# Altium schematic sheet BatteryCharger.SchDoc
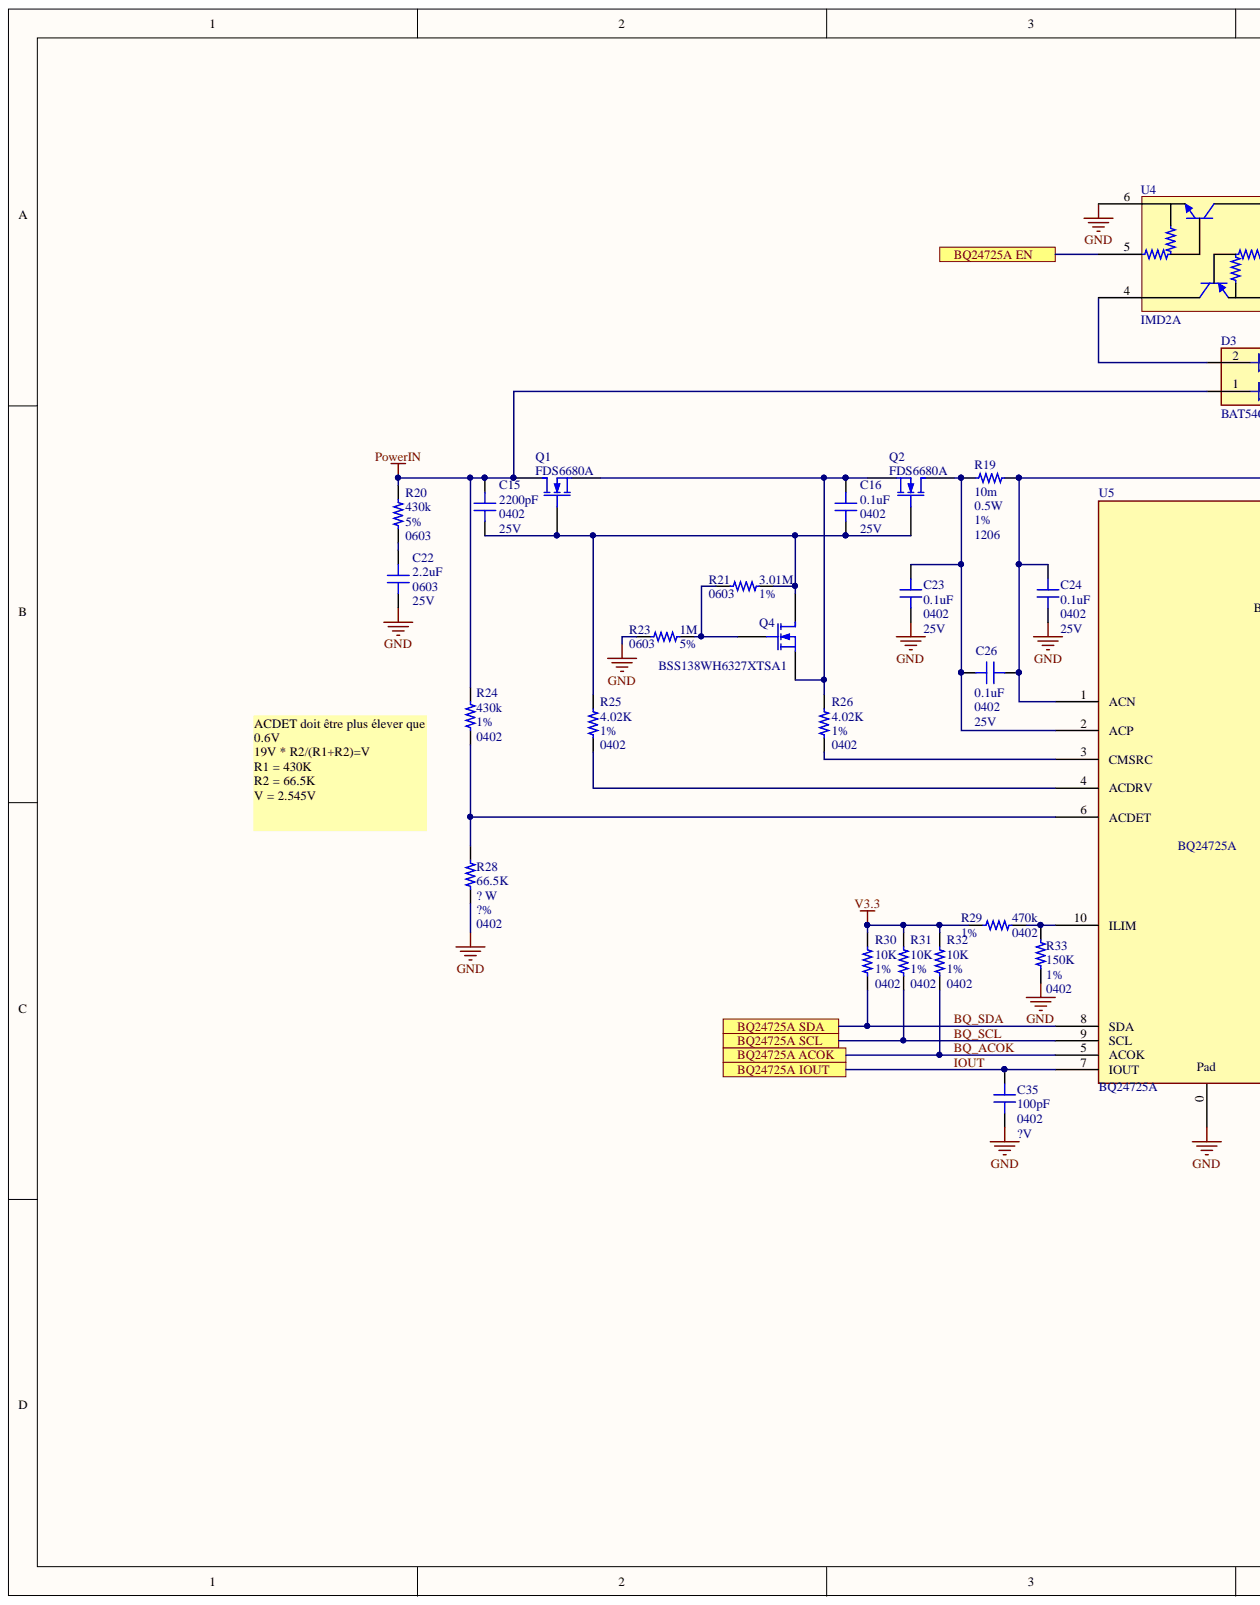
[diagram p0: BatteryCharger.SchDoc, left]
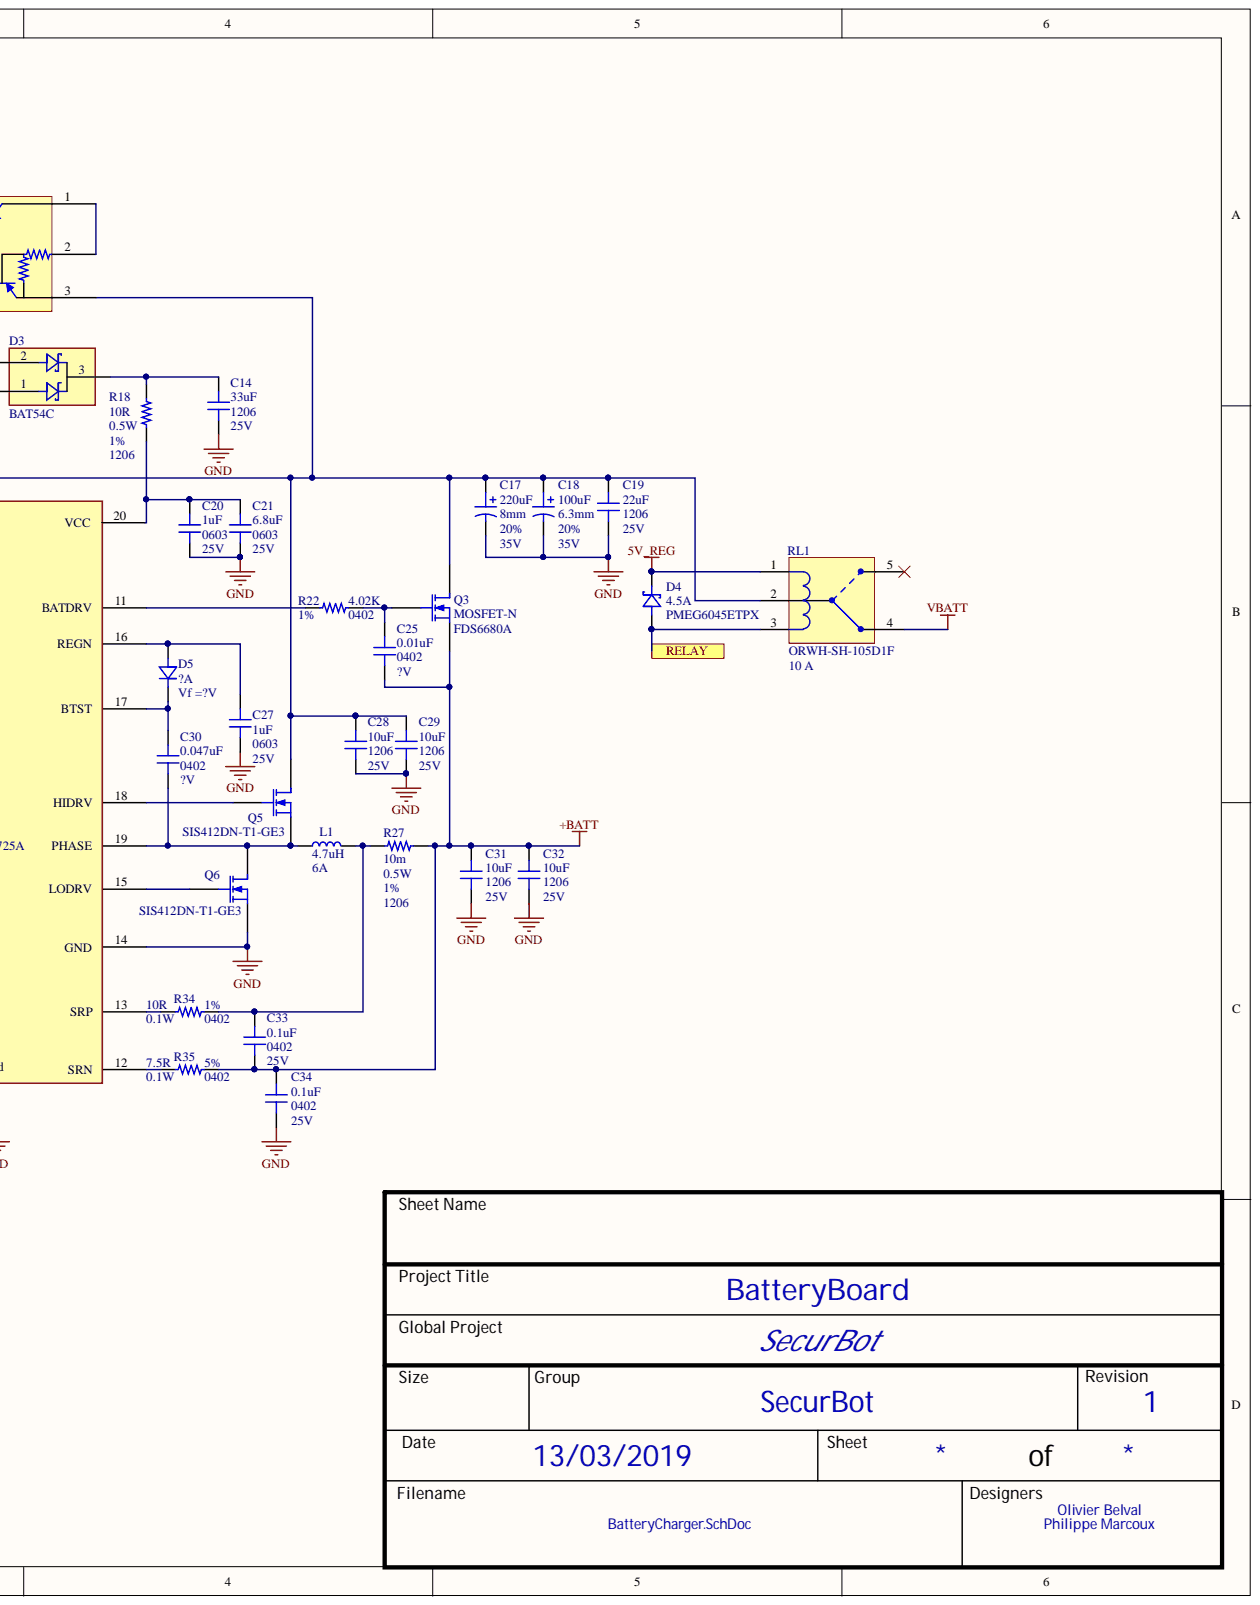
[diagram p1: BatteryCharger.SchDoc, right]

## Parts
C14  value 33uF  Package: 1206  Voltage: 25V  [CAP_1206]  (hidden comment: CAP - 1206)
C15  value 2200pF  Package: 0402  Voltage: 25V  [CAP_0402]  (hidden comment: CAP - 0402)
C16  value 0.1uF  Package: 0402  Voltage: 25V  [CAP_0402]  (hidden comment: CAP - 0402)
C17  value 220uF  Package: 8mm  Tolerance: 20%  Voltage: 35V  [CAP UWT 8x10]  (hidden comment: CAP ALU - 6.3mm)
C18  value 100uF  Package: 6.3mm  Tolerance: 20%  Voltage: 35V  [CAP ALU - 6.3mm DIA]  (hidden comment: CAP ALU - 6.3mm)
C19  value 22uF  Package: 1206  Voltage: 25V  [CAP_1206]  (hidden comment: CAP - 1206)
C20  value 1uF  Package: 0603  Voltage: 25V  [CAP_0603]  (hidden comment: CAP - 0603)
C21  value 6.8uF  Package: 0603  Voltage: 25V  [CAP_0603]  (hidden comment: CAP - 0603)
C22  value 2.2uF  Package: 0603  Voltage: 25V  [CAP_0603]  (hidden comment: CAP - 0603)
C23  value 0.1uF  Package: 0402  Voltage: 25V  [CAP_0402]  (hidden comment: CAP - 0402)
C24  value 0.1uF  Package: 0402  Voltage: 25V  [CAP_0402]  (hidden comment: CAP - 0402)
C25  value 0.01uF  Package: 0402  Voltage: ?V  [CAP_0402]  (hidden comment: CAP - 0402)
C26  value 0.1uF  Package: 0402  Voltage: 25V  [CAP_0402]  (hidden comment: CAP - 0402)
C27  value 1uF  Package: 0603  Voltage: 25V  [CAP_0603]  (hidden comment: CAP - 0603)
C28  value 10uF  Package: 1206  Voltage: 25V  [CAP_1206]  (hidden comment: CAP - 1206)
C29  value 10uF  Package: 1206  Voltage: 25V  [CAP_1206]  (hidden comment: CAP - 1206)
C30  value 0.047uF  Package: 0402  Voltage: ?V  [CAP_0402]  (hidden comment: CAP - 0402)
C31  value 10uF  Package: 1206  Voltage: 25V  [CAP_1206]  (hidden comment: CAP - 1206)
C32  value 10uF  Package: 1206  Voltage: 25V  [CAP_1206]  (hidden comment: CAP - 1206)
C33  value 0.1uF  Package: 0402  Voltage: 25V  [CAP_0402]  (hidden comment: CAP - 0402)
C34  value 0.1uF  Package: 0402  Voltage: 25V  [CAP_0402]  (hidden comment: CAP - 0402)
C35  value 100pF  Package: 0402  Voltage: ?V  [CAP_0402]  (hidden comment: CAP - 0402)
D3  BAT54C  [BAT54C]
D4  Current: 4.5A  Part number: PMEG6045ETPX  [DO-214AC_SOD128]  (hidden comment: SCHOTTKEY)
D5  Current: ?A  [BAT54]  (hidden comment: DIODE BAT54)
L1  value 4.7uH  Current: 6A  [ASPI-0630LR]  (hidden comment: IND)
Q1  Part number: FDS6680A  [SO-8 FDS6680AS]  (hidden comment: MOSFET-N)
Q2  Part number: FDS6680A  [SO-8 FDS6680AS]  (hidden comment: MOSFET-N)
Q3  MOSFET-N  Part number: FDS6680A  [SO-8 FDS6680AS]
Q4  Part number: BSS138WH6327XTSA1  [PG-SOT-323]  (hidden comment: MOSFET-N)
Q5  Part number: SIS412DN-T1-GE3  [SiS412DN]  (hidden comment: MOSFET-N)
Q6  Part number: SIS412DN-T1-GE3  [SiS412DN]  (hidden comment: MOSFET-N)
R18  value 10R  Package: 1206  Tolerance: 1%  Watt: 0.5W  [RES_1206]  (hidden comment: RES - 1206)
R19  value 10m  Watt: 0.5W  [RES_1206]  (hidden comment: RES - 1206)
R20  value 430k  Package: 0603  Tolerance: 5%  [RES_0603]  (hidden comment: RES - 0603)
R21  value 3.01M  Package: 0603  Tolerance: 1%  [RES_0603]  (hidden comment: RES - 0603)
R22  value 4.02K  Package: 0402  Tolerance: 1%  [RES_0402]  (hidden comment: RES - 0402)
R23  value 1M  Package: 0603  Tolerance: 5%  [RES_0603]  (hidden comment: RES - 0603)
R24  value 430k  Package: 0402  Tolerance: 1%  [RES_0402]  (hidden comment: RES - 0402)
R25  value 4.02K  Package: 0402  Tolerance: 1%  [RES_0402]  (hidden comment: RES - 0402)
R26  value 4.02K  Package: 0402  Tolerance: 1%  [RES_0402]  (hidden comment: RES - 0402)
R27  value 10m  Tolerance: 1%  Watt: 0.5W  [RES_1206]  (hidden comment: RES - 1206)
R28  value 66.5K  Package: 0402  Tolerance: ?%  Watt: ? W  [RES_0402]  (hidden comment: RES - 0402)
R29  value 470k  Package: 0402  Tolerance: 1%  [RES_0402]  (hidden comment: RES - 0402)
R30  value 10K  Package: 0402  Tolerance: 1%  [RES_0402]  (hidden comment: RES - 0402)
R31  value 10K  Package: 0402  Tolerance: 1%  [RES_0402]  (hidden comment: RES - 0402)
R32  value 10K  Package: 0402  Tolerance: 1%  [RES_0402]  (hidden comment: RES - 0402)
R33  value 150K  Package: 0402  Tolerance: 1%  [RES_0402]  (hidden comment: RES - 0402)
R34  value 10R  Package: 0402  Tolerance: 1%  Watt: 0.1W  [RES_0402]  (hidden comment: RES - 0402)
R35  value 7.5R  Package: 0402  Tolerance: 5%  Watt: 0.1W  [RES_0402]  (hidden comment: RES - 0402)
RL1  A max: 10 A  Part number: ORWH-SH-105D1F  [ORWH-SH]  (hidden comment: Relay SPDT)
U4  IMD2A  [IMD2A]
U5  BQ24725A  [bq24725A]

## Ports
BQ24725A ACOK, BQ24725A EN, BQ24725A IOUT, BQ24725A SCL, BQ24725A SDA, RELAY

## Nets
5V_REG: D4.2 RL1.1
+BATT: C25.1 C31.1 C32.1 C33.1 C34.2 Q3.3 R27.2 R35.2
GND: C14.2 C17.2 C18.2 C19.2 C20.2 C21.2 C22.2 C23.1 C24.1 C27.2 C28.2 C29.2 C31.2 C32.2 C34.1 C35.2 Q6.3 R23.1 R28.2 R33.2 U4.6 U5.0 U5.14(GND)
PowerIN: C15.2 D3.1 Q1.1 R20.1 R24.1
V3.3: R29.2 R30.1 R31.1 R32.1
VBATT: RL1.4
BQ24725A EN: U4.5 ; port BQ24725A EN
BQ_ACOK: R32.2 U5.5(ACOK) ; port BQ24725A ACOK
BQ_SCL: R31.2 U5.9(SCL) ; port BQ24725A SCL
BQ_SDA: R30.2 U5.8(SDA) ; port BQ24725A SDA
IOUT: C35.1 U5.7(IOUT) ; port BQ24725A IOUT
RELAY: D4.1 RL1.3 ; port RELAY
NetC14_1: C14.1 D3.3 R18.2
NetC15_1: C15.1 C16.1 Q1.2 Q2.2 Q4.3 R21.2 R25.1
NetC16_2: C16.2 Q1.3 Q2.3 Q4.2 R26.1
NetC17_1: C17.1 C18.1 C19.1 C24.2 C26.1 C28.1 C29.1 Q3.1 Q5.1 R19.2 RL1.2 U4.3 U5.1(ACN)
NetC20_1: C20.1 C21.1 R18.1 U5.20(VCC)
NetC22_1: C22.1 R20.2
NetC23_2: C23.2 C26.2 Q2.1 R19.1 U5.2(ACP)
NetC25_2: C25.2 Q3.2 R22.2
NetC27_1: C27.1 D5.1 U5.16(REGN)
NetC30_1: C30.1 D5.3 U5.17(BTST)
NetC30_2: C30.2 L1.1 Q5.3 Q6.1 U5.19(PHASE)
NetC33_2: C33.2 L1.2 R27.1 R34.2
NetD3_2: D3.2 U4.4
NetQ4_1: Q4.1 R21.1 R23.2
NetQ5_2: Q5.2 U5.18(HIDRV)
NetQ6_2: Q6.2 U5.15(LODRV)
NetR22_1: R22.1 U5.11(BATDRV)
NetR24_2: R24.2 R28.1 U5.6(ACDET)
NetR25_2: R25.2 U5.4(ACDRV)
NetR26_2: R26.2 U5.3(CMSRC)
NetR29_1: R29.1 R33.1 U5.10(ILIM)
NetR34_1: R34.1 U5.13(SRP)
NetR35_1: R35.1 U5.12(SRN)
NetU4_1: U4.1 U4.2

Not connected: RL1 5

## Library data of the parts
C14 = CAP - 1206: Part number: C3216JB1E336M160AC; Component Kind: Standard; Description: CAP - 1206; Library Name: SecureBot.SchLib
C15 = CAP - 0402: Part number: AC0402KRX7R8BB222; Component Kind: Standard; Description: CAP - 0402; Library Name: SecureBot.SchLib
C16 C23 C24 C26 C33 C34 = CAP - 0402: Part number: CL05A104KA5NNNC; Component Kind: Standard; Description: CAP - 0402; Library Name: SecureBot.SchLib
C17 = CAP ALU - 6_3mm: Part number: UWT1E221MNL1GS; Component Kind: Standard; Description: CAP ALU - 6.3mm; Library Name: SecureBot.SchLib
C18 = CAP ALU - 6_3mm: Part number: UWT1E101MCL1GS; Component Kind: Standard; Description: CAP ALU - 6.3mm; Library Name: SecureBot.SchLib
C19 = CAP - 1206: Part number: CL31A226KAHNNNE; Component Kind: Standard; Description: CAP - 1206; Library Name: SecureBot.SchLib
C20 C27 = CAP - 0603: Part number: CL10A105KA8NNNC; Component Kind: Standard; Description: CAP - 0603; Library Name: SecureBot.SchLib
C21 = CAP - 0603: Part number: C1608X5R1E685K080AC; Component Kind: Standard; Description: CAP - 0603; Library Name: SecureBot.SchLib
C22 = CAP - 0603: Part number: GRM188R61E225KA12D; Component Kind: Standard; Description: CAP - 0603; Library Name: SecureBot.SchLib
C25 = CAP - 0402: Part number: CL05B103KA5NNNC; Component Kind: Standard; Description: CAP - 0402; Library Name: SecureBot.SchLib
C28 C29 C31 C32 = CAP - 1206: Part number: CL31A106KAHNFNE; Component Kind: Standard; Description: CAP - 1206; Library Name: SecureBot.SchLib
C30 = CAP - 0402: Part number: C0402C473K3RACAUTO; Component Kind: Standard; Description: CAP - 0402; Library Name: SecureBot.SchLib
C35 = CAP - 0402: Part number: CC0402KRX7R8BB101; Component Kind: Standard; Description: CAP - 0402; Library Name: SecureBot.SchLib
D4 = SCHOTTKEY: Vf: Vf =0.33V; Component Kind: Standard; Library Name: SecureBot.SchLib
D5 = DIODE BAT54: Part number: BAT54C; Vf: Vf =?V; Component Kind: Standard; Library Name: SecureBot.SchLib
L1 = IND: Part number: ASPI-0630LR-4R7M-T15; Component Kind: Standard; Description: IND; Package: ?; Library Name: SecureBot.SchLib
Q1-Q6 = MOSFET-N: N-Channel MOSFET; Component Kind: Standard; LatestRevisionDate: 17-Jul-2002; LatestRevisionNote: Re-released for DXP Platform.; Library Name: Miscellaneous Devices.IntLib; PackageDescription: TO, Flat Index; 3 In-Line, Axial Leads; Body Dia. 4.6mm; Lead Dia. 0.5 mm (max); PackageReference: E3; PackageVersion: 1995/1996; Published: 8-Jun-2000; Simulation: NMOS; Publisher: Altium Limited
R18 = RES - 1206: Part number: CRM1206-FX-10R0ELF; Component Kind: Standard; Description: RES - 1206; Library Name: SecureBot.SchLib
R19 = RES - 1206: Part number: KRL1632E-M-R010-F-T5; Tolerance: 1%; Component Kind: Standard; Description: RES - 1206; Package: 1206; Library Name: SecureBot.SchLib
R20 = RES - 0603: Part number: RC0603JR-07430KL; Watt: 0.1W; Component Kind: Standard; Description: RES - 0603; Library Name: SecureBot.SchLib
R21 = RES - 0603: Part number: RC0603FR-073M01L; Watt: ? W; Component Kind: Standard; Description: RES - 0603; Library Name: SecureBot.SchLib
R22 R25 R26 = RES - 0402: Part number: ERJ-2RKF4021X; Watt: ? W; Component Kind: Standard; Description: RES - 0402; Library Name: SecureBot.SchLib
R23 = RES - 0603: Part number: CRGCQ0603J1M0; Watt: 0.1 W; Component Kind: Standard; Description: RES - 0603; Library Name: SecureBot.SchLib
R24 = RES - 0402: Part number: RC0402FR-07430KL; Watt: ? W; Component Kind: Standard; Description: RES - 0402; Library Name: SecureBot.SchLib
R27 = RES - 1206: Part number: KRL1632E-M-R010-F-T5; Component Kind: Standard; Description: RES - 1206; Package: 1206; Library Name: SecureBot.SchLib
R28 = RES - 0402: Part number: RMCF0402FT66K5; Component Kind: Standard; Description: RES - 0402; Library Name: SecureBot.SchLib
R29 = RES - 0402: Part number: MCS04020C4703FE000; Watt: ? W; Component Kind: Standard; Description: RES - 0402; Library Name: SecureBot.SchLib
R30-R32 = RES - 0402: Part number: MCS0402MC1002FE000; Watt: ? W; Component Kind: Standard; Description: RES - 0402; Library Name: SecureBot.SchLib
R33 = RES - 0402: Part number: MCS04020C1503FE000; Watt: ? W; Component Kind: Standard; Description: RES - 0402; Library Name: SecureBot.SchLib
R34 = RES - 0402: Part number: ERJ-2RKF10R0X; Component Kind: Standard; Description: RES - 0402; Library Name: SecureBot.SchLib
R35 = RES - 0402: Part number: ERJ-2GEJ7R5X; Component Kind: Standard; Description: RES - 0402; Library Name: SecureBot.SchLib
U4 = IMD2A: Dual digital Transistor; Component Kind: Standard; Library Name: SecureBot.SchLib
D3 = BAT54C: Component Kind: Standard; Part number: BAT54C; Library Name: SecureBot.SchLib
RL1 = Relay SPDT: Component Kind: Standard; Library Name: SecureBot.SchLib
U5 = BQ24725A: Component Kind: Standard; Library Name: SecureBot.SchLib
